# Altium schematic sheet MAIN.SchDoc(MAIN)
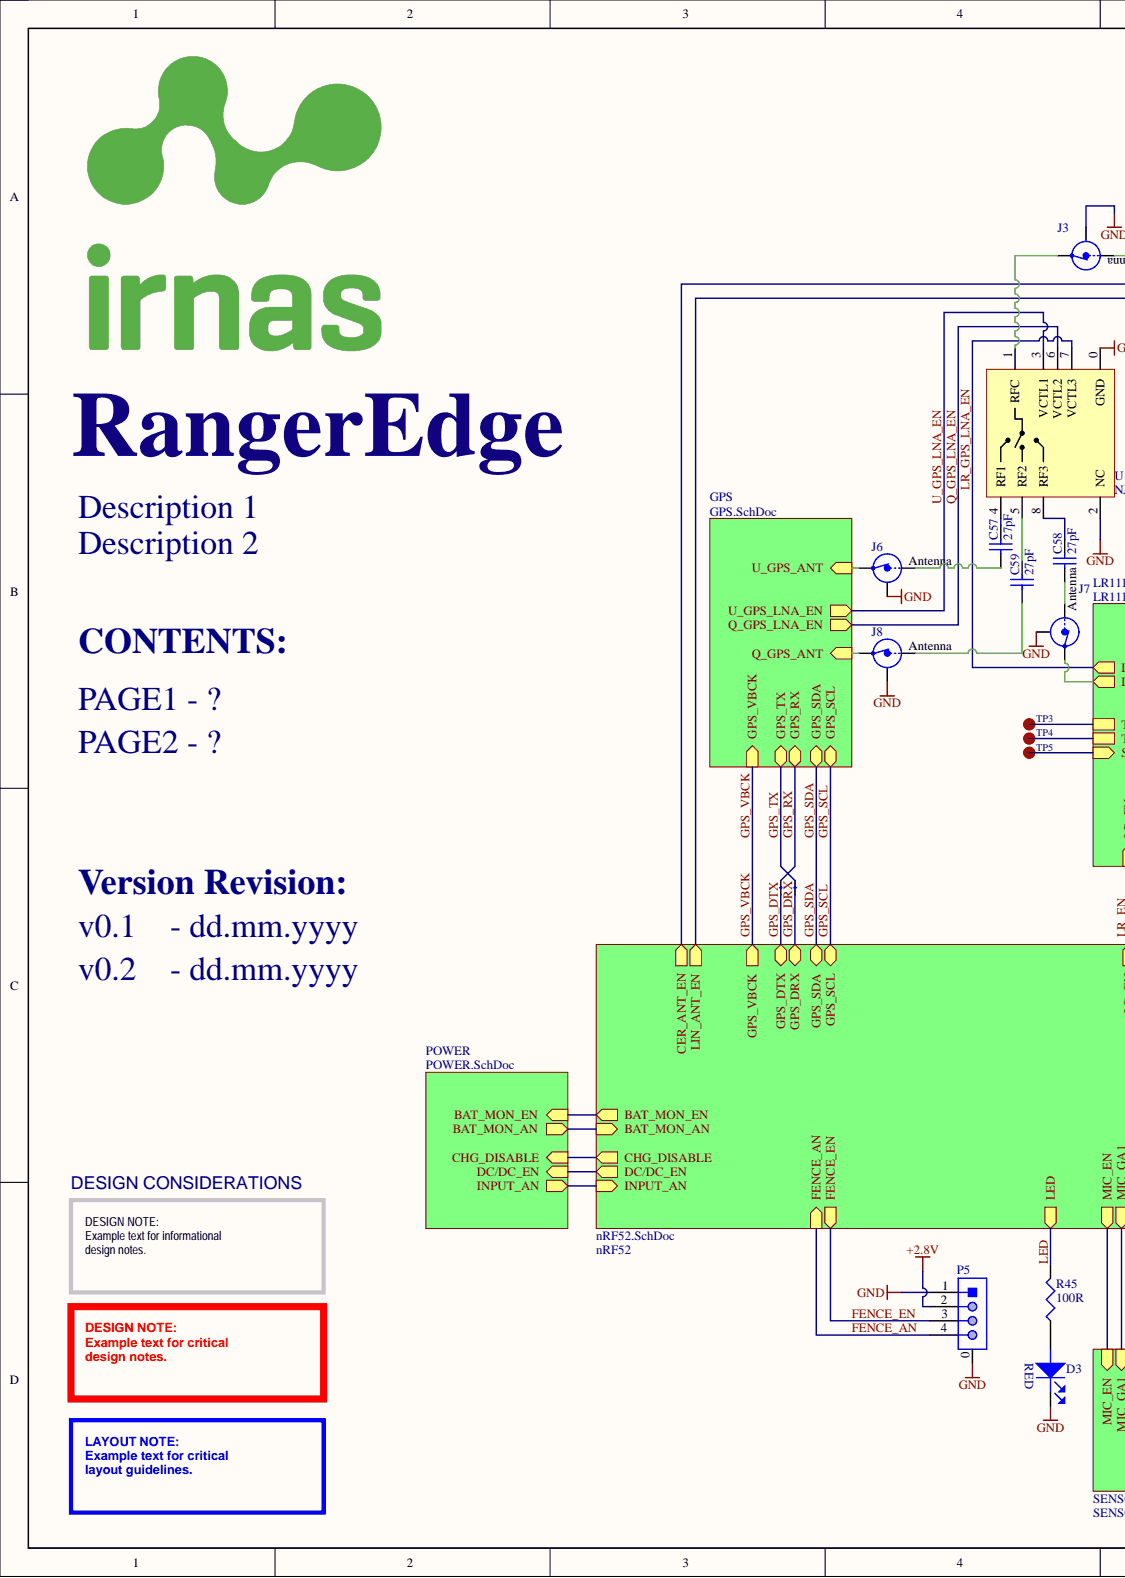
[diagram p0: MAIN.SchDoc, left]
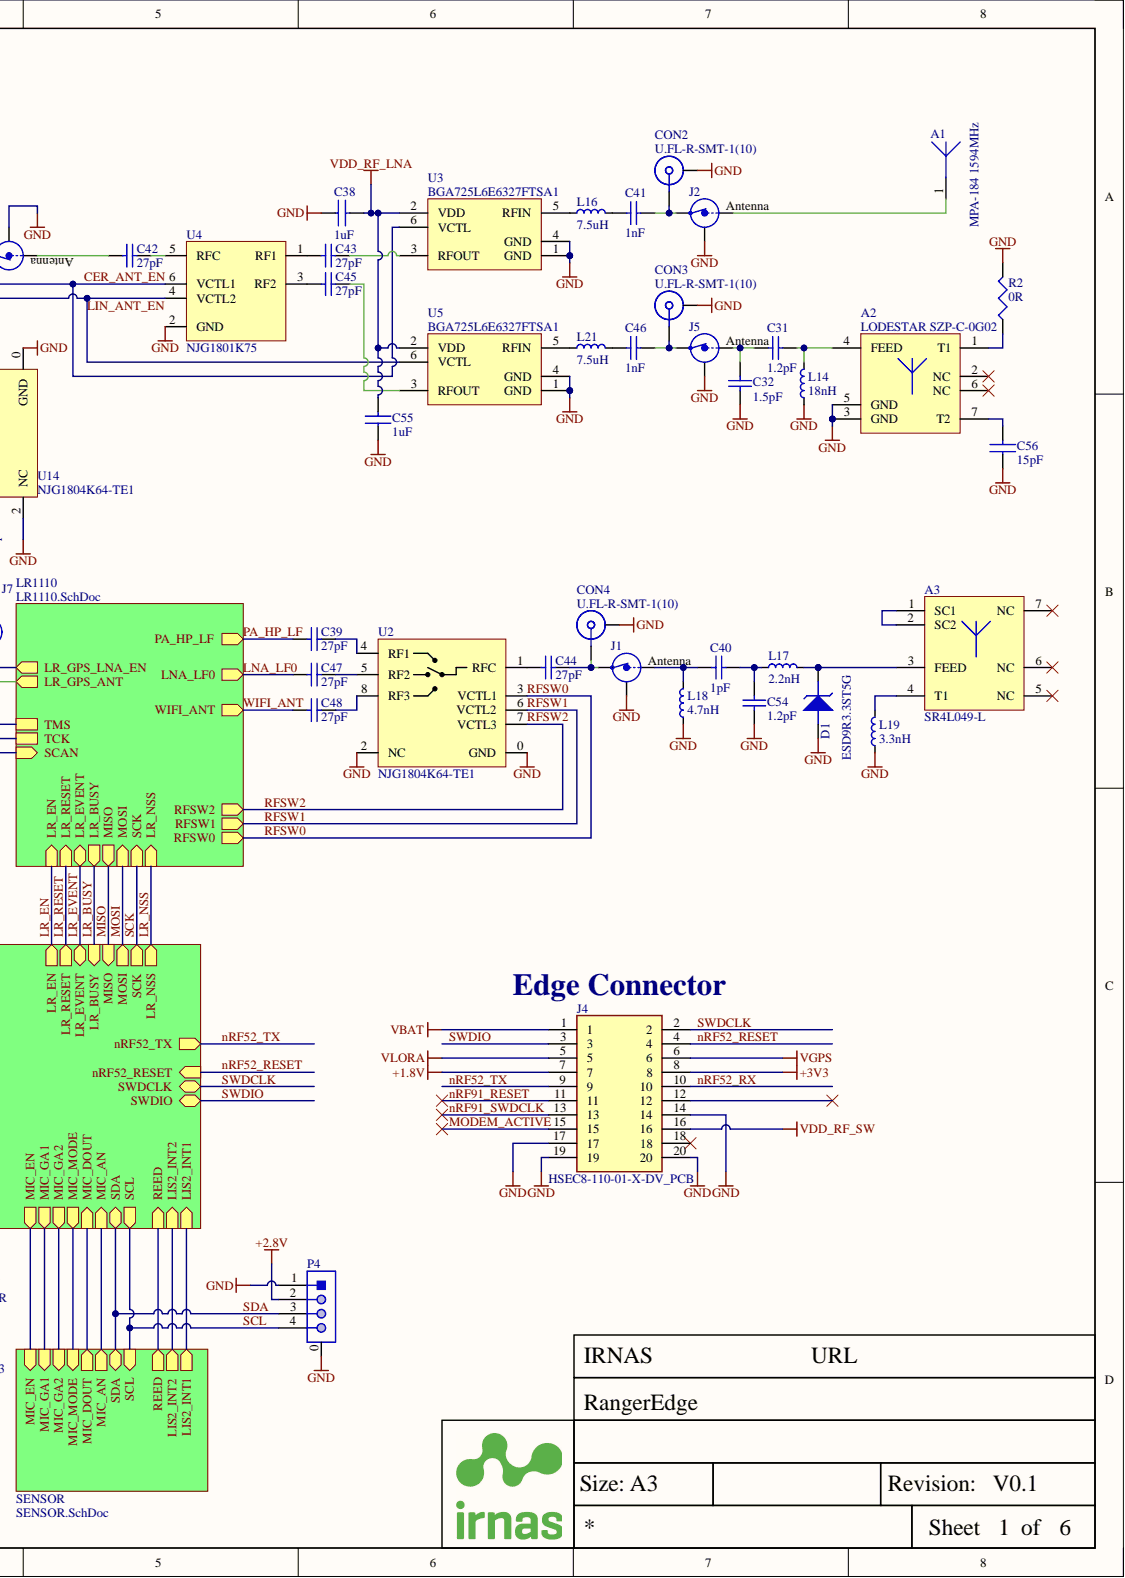
[diagram p1: MAIN.SchDoc, right]

## Parts
A1  MPA-184 1594MHz  [MPA-184]
A2  LODESTAR SZP-C-0G02  Manufacturer Part Number: SZP-C-0G02  [SZP-C-0G02-1]
A3  SR4L049-L  [SR4L049-L]
C31  value 1.2pF  [rlc_402_smd_small]  (hidden comment: 1.2pF/50V/0402)
C32  value 1.5pF  [rlc_402_smd_small]  (hidden comment: 1.5pF/50V/0402)
C38  1uF  [rlc_402_smd_small]
C39  value 27pF  [CAP0402]  (hidden comment: 27pF/50V/0402)
C40  value 1pF  [CAP0402]  (hidden comment: 1pF/50V/0402)
C41  value 1nF  [rlc_402_smd_small]  (hidden comment: 1nF/50V/0402)
C42  value 27pF  [rlc_402_smd_small]  (hidden comment: 27pF/50V/0402)
C43  value 27pF  [rlc_402_smd_small]  (hidden comment: 27pF/50V/0402)
C44  value 27pF  [CAP0402]  (hidden comment: 27pF/50V/0402)
C45  value 27pF  [rlc_402_smd_small]  (hidden comment: 27pF/50V/0402)
C46  value 1nF  [rlc_402_smd_small]  (hidden comment: 1nF/50V/0402)
C47  value 27pF  [CAP0402]  (hidden comment: 27pF/50V/0402)
C48  value 27pF  [CAP0402]  (hidden comment: 27pF/50V/0402)
C54  value 1.2pF  [CAP0402]  (hidden comment: 1.2pF/50V/0402)
C55  1uF  [CAP0402]
C56  15pF  [CAP0402]
C57  value 27pF  [rlc_402_smd_small]  (hidden comment: 27pF/50V/0402)
C58  value 27pF  [rlc_402_smd_small]  (hidden comment: 27pF/50V/0402)
C59  value 27pF  [rlc_402_smd_small]  (hidden comment: 27pF/50V/0402)
CON2  U.FL-R-SMT-1(10)  [ufl connector smd]
CON3  U.FL-R-SMT-1(10)  [ufl connector smd]
CON4  U.FL-R-SMT-1(10)  [ufl connector smd]
D1  ESD9R3.3ST5G  [SOD-923]
D3  KPTL-3216SURCK-01  [LED1206 - Reverse]  (value not drawn)
J1  MM8130-2600  [COAXIAL-SWF]  (value not drawn)
J2  MM8130-2600  [COAXIAL-SWF]  (value not drawn)
J3  MM8130-2600  [COAXIAL-SWF]  (value not drawn)
J4  HSEC8-110-01-X-DV_PCB  [SAMTEC_HSEC8-110-01-X-DV_PCB]  (no BOM)
J5  MM8130-2600  [COAXIAL-SWF]  (value not drawn)
J6  MM8130-2600  [COAXIAL-SWF]  (value not drawn)
J7  MM8130-2600  [COAXIAL-SWF]  (value not drawn)
J8  MM8130-2600  [COAXIAL-SWF]  (value not drawn)
L14  value 18nH  [rlc_402_smd_small]  (hidden comment: 18nH/0402)
L16  value 7.5uH  [rlc_402_smd_small]  (hidden comment: 7.5nH/0402)
L17  2.2nH  [IND0402]
L18  4.7  value 4.7nH  [IND0402]
L19  value 3.3nH  [IND0402]  (hidden comment: 3.3nH/0402)
L21  value 7.5uH  [rlc_402_smd_small]  (hidden comment: 7.5nH/0402)
NT1  =Value  [2 PIN STARPOINT]
NT2  =Value  [2 PIN STARPOINT]
P4  BM04B-SRSS-TB(LF)(SN)  [BM04B-SRSS]  (value not drawn)
P5  BM04B-SRSS-TB(LF)(SN)  [BM04B-SRSS]  (value not drawn)
R2  0R  [RES0402]
R45  100R  [RES0402]
TP3  TestPoint  [TestPoint:1.2x1.2mm]  (value not drawn)
TP4  TestPoint  [TestPoint:1.2x1.2mm]  (value not drawn)
TP5  TestPoint  [TestPoint:1.2x1.2mm]  (value not drawn)
U2  NJG1804K64-TE1  [DFN-8]
U3  BGA725L6E6327FTSA1  [EPFFP6-X2]
U4  NJG1801K75  [DFN-6-JRC]
U5  BGA725L6E6327FTSA1  [EPFFP6-X2]
U14  NJG1804K64-TE1  [DFN-8]

## Nets
+1.8V: J4.7
+2.8V: P4.2 P5.2
+3V3: J4.8
GND: A2.3(GND) A2.5(GND) C32.2 C38.1 C54.1 C55.1 C56.1 CON2.2 CON3.2 CON4.2 D1.A D3.2 J1.2 J2.2 J3.2 J4.14 J4.17 J4.19 J4.20 J5.2 J6.2 J7.2 J8.2 L14.1 L18.1 L19.1 P4.0 P4.1 P5.0 P5.1 R2.2 U2.0(GND) U2.2(NC) U3.1(GND) U3.4(GND) U4.2(GND) U5.1(GND) U5.4(GND) U14.0(GND) U14.2(NC)
VBAT: J4.1
VDD_RF_LNA: C38.2 C55.2 U3.2(VDD) U5.2(VDD)
VDD_RF_SW: J4.16
VGPS: J4.6
VLORA: J4.5
CER_ANT_EN: U3.6(VCTL) U4.6(VCTL1)
FENCE_AN: P5.4
FENCE_EN: P5.3
GPS_DRX: NT2.1
GPS_DTX: NT1.1
GPS_RX: NT1.2
GPS_TX: NT2.2
LED: R45.2
LIN_ANT_EN: U4.4(VCTL2) U5.6(VCTL)
LNA_LF0: C47.1
LR_GPS_LNA_EN: U14.7(VCTL3)
MODEM_ACTIVE: J4.15
nRF52_RESET: J4.4
nRF52_RX: J4.10
nRF52_TX: J4.9
nRF91_RESET: J4.11
nRF91_SWDCLK: J4.13
PA_HP_LF: C39.1
Q_GPS_LNA_EN: U14.6(VCTL2)
RFSW0: U2.3(VCTL1)
RFSW1: U2.6(VCTL2)
RFSW2: U2.7(VCTL3)
SCL: P4.4
SDA: P4.3
SWDCLK: J4.2
SWDIO: J4.3
U_GPS_LNA_EN: U14.3(VCTL1)
WIFI_ANT: C48.1
NetA1_1: A1.1 J2.1
NetA2_1: A2.1(T1) R2.1
NetA2_2: A2.2(NC)
NetA2_4: A2.4(FEED) C31.2 L14.2
NetA2_6: A2.6(NC)
NetA2_7: A2.7(T2) C56.2
NetA3_1: A3.1(SC1) A3.2(SC2)
NetA3_3: A3.3(FEED) D1.K L17.2
NetA3_4: A3.4(T1) L19.2
NetA3_5: A3.5(NC)
NetA3_6: A3.6(NC)
NetA3_7: A3.7(NC)
NetC31_1: C31.1 C32.1 J5.1
NetC39_2: C39.2 U2.4(RF1)
NetC40_1: C40.1 C54.2 L17.1
NetC40_2: C40.2 J1.1 L18.2
NetC41_1: C41.1 L16.2
NetC41_2: C41.2 CON2.1 J2.3
NetC42_1: C42.1 J3.1
NetC42_2: C42.2 U4.5(RFC)
NetC43_1: C43.1 U4.1(RF1)
NetC43_2: C43.2 U3.3(RFOUT)
NetC44_1: C44.1 U2.1(RFC)
NetC44_2: C44.2 CON4.1 J1.3
NetC45_1: C45.1 U4.3(RF2)
NetC45_2: C45.2 U5.3(RFOUT)
NetC46_1: C46.1 L21.2
NetC46_2: C46.2 CON3.1 J5.3
NetC47_2: C47.2 U2.5(RF2)
NetC48_2: C48.2 U2.8(RF3)
NetC57_1: C57.1 J6.1
NetC57_2: C57.2 U14.4(RF1)
NetC58_1: C58.1 J7.1
NetC58_2: C58.2 U14.8(RF3)
NetC59_1: C59.1 J8.1
NetC59_2: C59.2 U14.5(RF2)
NetD3_1: D3.1 R45.1
NetJ3_3: J3.3 U14.1(RFC)
NetJ4_12: J4.12
NetJ4_18: J4.18
NetJ6_3: J6.3
NetJ7_3: J7.3
NetJ8_3: J8.3
NetL16_1: L16.1 U3.5(RFIN)
NetL21_1: L21.1 U5.5(RFIN)
NetTP3_0: TP3.0
NetTP4_0: TP4.0
NetTP5_0: TP5.0
BAT_MON_AN:
BAT_MON_EN:
CHG_DISABLE:
DC/DC_EN:
GPS_SCL:
GPS_SDA:
GPS_VBCK:
INPUT_AN:
LIS2_INT1:
LIS2_INT2:
LR_BUSY:
LR_EN:
LR_EVENT:
LR_NSS:
LR_RESET:
MIC_AN:
MIC_DOUT:
MIC_EN:
MIC_GA1:
MIC_GA2:
MIC_MODE:
MISO:
MOSI:
REED:
SCK:

## Library data of the parts
A1 = ANT - MAXTENA MPA-184 1594MHz: Antennas Passive GPS/GLONASS Patch Antenna; Manufacturer: Antenova; Manufacturer Part Number: SR42I010-R; Component Kind: Standard; ComponentLink1Description: Datasheet; ComponentLink1URL: https://www.maxtena.com/wp-content/uploads/2018/09/maxtena_application_note_patch_antennas.pdf; Library Name: Passive.SchLib; Pricing 1: 1 = 2.36000, 10 = 1.90000, 50 = 1.90000, 100 = 1.52000, 1000 = 1.07000, 10000 = 0.95900 (USD); Stock 1: 3154; Supplier 1: Digi-Key; Supplier 2: Mouser; Supplier Part Number 1: 931-1408-ND; Supplier Part Number 2: 960-CGGP.18.2.A.02
A2 = ANT - LODESTAR SZP-C-0G02: GNSS: GPS, GLONASS, GALILEO, Beidou: 1.559 – 1.610 GHz; Manufacturer: SYNZEN; Component Kind: Standard; Library Name: Passive.SchLib; Pricing 1: 1 = 2.36000, 10 = 1.90000, 50 = 1.90000, 100 = 1.52000, 1000 = 1.07000, 10000 = 0.95900 (USD); Stock 1: 3154
A3 = ANT - SR4L049-L: Antennas Inversa Left LTE Antenna; Manufacturer: Antenova; Component Kind: Standard; Library Name: Passive.SchLib; Manufacturer Part Number: SR4L049-L; Pricing 1: 1 = 2.36000, 10 = 1.90000, 50 = 1.90000, 100 = 1.52000, 1000 = 1.07000, 10000 = 0.95900 (USD); Stock 1: 3154; Supplier 1: Mouser; Supplier Part Number 1: 237-SR4L049-L
C31 = C - 1.2pF/50V/0402: CAP 1.2PF 50V C0G/NP0 0402; IRNAS Part No.: /; Manufacturer: Murata; Manufacturer Part Number: GRM1555C1H1R2BA01D; Tolerance: ±0.1pF; Component Kind: Standard; Library Name: Passive.SchLib; Supplier 1: Digi-Key; Supplier Part Number 1: 490-6209-1-ND; Voltage: 50V
C32 = C - 1.5pF/50V/0402: CAP 1.5PF 50V C0G/NP0 0402; IRNAS Part No.: /; Manufacturer: Murata; Manufacturer Part Number: GRM1555C1H1R5BA01D; Tolerance: ±0.1pF; Component Kind: Standard; Library Name: Passive.SchLib; Supplier 1: Digi-Key; Supplier Part Number 1: 490-6212-1-ND; Voltage: 50V
C38 C55 = C - 1uF/0402: CAP CER 1UF 10V 20% X5R 0402; IRNAS Part No.: /; Manufacturer: Murata; Manufacturer Part Number: GRM155R61A105ME15D; Capacitance: 1uF; Case Code (Imperial): 0402; Case Code (Metric): 1005; Case/Package: 0402; Component Kind: Standard; ComponentLink1Description: https://datasheet.ciiva.com/164/product-164665.pdf; ComponentLink1URL: https://datasheet.ciiva.com/164/product-164665.pdf; ComponentLink2Description: https://datasheet.ciiva.com/11616/product-11616508.pdf; ComponentLink2URL: https://datasheet.ciiva.com/11616/product-11616508.pdf; ComponentLink3Description: https://datasheet.ciiva.com/46418/1769893-46418047.pdf; ComponentLink3URL: https://datasheet.ciiva.com/46418/1769893-46418047.pdf; ComponentLink4Description: https://datasheet.ciiva.com/164/partnumbering-e-01-164666.pdf; ComponentLink4URL: https://datasheet.ciiva.com/164/partnumbering-e-01-164666.pdf; ComponentLink5Description: https://datasheet.ciiva.com/164/partnumbering-e-01-164667.pdf; ComponentLink5URL: https://datasheet.ciiva.com/164/partnumbering-e-01-164667.pdf; Depth: 0.5mm; Dielectric: X5R; Height: 0.5mm; Lead Free: Lead Free; Length: 1mm; Library Name: Passive.SchLib; Max Operating Temperature: 85°C; Min Operating Temperature: -55°C; Mount: Surface Mount; Package Quantity: 1; Packaging: Tape and Reel; Pricing 1: 10=0.0379, 100=0.0266, 500=0.0156, 2500=0.0098, 5000=0.0083 (EUR); Radiation Hardening: No; REACH SVHC: No SVHC; Stock 1: 0; Supplier 1: Farnell; Supplier Part Number 1: 2426953; Thickness: 0.022inch; Tolerance: 20%; Voltage: 10V; Voltage Rating: 10V; Voltage Rating (DC): 10V; Width: 0.02inch
C39 C42-C45 C47 C48 C57-C59 = C - 27pF/50V/0402: CAP CER 27PF 50V C0G/NP0 0402; Component Kind: Standard; LatestRevisionDate: 05-oct-2007  -  Semtech; LatestRevisionNote: Re-released for DXP Platform.; Library Name: Passive.SchLib; Published: 8-Jun-2000; Supplier 1: Digi-Key; Supplier Part Number 1: 490-11384-1-ND; Publisher: Altium Limited
C40 = C - 1pF/50V/0402: CAP CER 1PF 50V C0G/NP0 0402; Component Kind: Standard; LatestRevisionDate: 05-oct-2007  -  Semtech; LatestRevisionNote: Re-released for DXP Platform.; Library Name: Passive.SchLib; Published: 8-Jun-2000; Supplier 1: Digi-Key; Supplier Part Number 1: 490-6206-1-ND; Publisher: Altium Limited
C41 C46 = C - 1nF/50V/0402: CAP CER 1nF 50V C0G/NP0 0402; Component Kind: Standard; LatestRevisionDate: 05-oct-2007  -  Semtech; LatestRevisionNote: Re-released for DXP Platform.; Library Name: Passive.SchLib; Published: 8-Jun-2000; Supplier 1: Farnell; Supplier Part Number 1: 2470415; Publisher: Altium Limited
C54 = C - 1.2pF/50V/0402: CAP CER 1.2PF 50V C0G/NP0 0402; Component Kind: Standard; LatestRevisionDate: 05-oct-2007  -  Semtech; LatestRevisionNote: Re-released for DXP Platform.; Library Name: Passive.SchLib; Published: 8-Jun-2000; Supplier 1: Digi-Key; Supplier Part Number 1: 490-6209-1-ND; Publisher: Altium Limited
C56 = C - 15pF/0402: AVX   04025A150JAT2A   Multilayer Ceramic Capacitor, 15 pF,  5%, C0G / NP0, 50 V, 0402 [1005 Metric]; Manufacturer: Kyocera AVX; Temperature Coefficient: 30ppm/°C; Voltage: 50V; Capacitance: 15pF; Case Code (Imperial): 0402; Case Code (Metric): 1005; Case/Package: 0402; Component Kind: Standard; ComponentLink1Description: https://datasheet.ciiva.com/11786/0900766b80def0e2-11786290.pdf; ComponentLink1URL: https://datasheet.ciiva.com/11786/0900766b80def0e2-11786290.pdf; ComponentLink2Description: https://datasheet.ciiva.com/28533/759117-28533749.pdf; ComponentLink2URL: https://datasheet.ciiva.com/28533/759117-28533749.pdf; ComponentLink3Description: https://datasheet.ciiva.com/1123/84496-1123526.pdf; ComponentLink3URL: https://datasheet.ciiva.com/1123/84496-1123526.pdf; ComponentLink4Description: https://datasheet.ciiva.com/1123/84496-1123527.pdf; ComponentLink4URL: https://datasheet.ciiva.com/1123/84496-1123527.pdf; ComponentLink5Description: https://datasheet.ciiva.com/14587/70001039-14587416.pdf; ComponentLink5URL: https://datasheet.ciiva.com/14587/70001039-14587416.pdf; ComponentLink6Description: https://datasheet.ciiva.com/17489/ccog-17489144.pdf; ComponentLink6URL: https://datasheet.ciiva.com/17489/ccog-17489144.pdf; ComponentLink7Description: https://datasheet.ciiva.com/156/ccog-156941.pdf; ComponentLink7URL: https://datasheet.ciiva.com/156/ccog-156941.pdf; ComponentLink8Description: https://datasheet.ciiva.com/156/ccog-156942.pdf; ComponentLink8URL: https://datasheet.ciiva.com/156/ccog-156942.pdf; ComponentLink9Description: https://datasheet.ciiva.com/2987/ccog-2987646.pdf; ComponentLink9URL: https://datasheet.ciiva.com/2987/ccog-2987646.pdf; ComponentLink10Description: https://datasheet.ciiva.com/7709/759117-7709534.pdf; ComponentLink10URL: https://datasheet.ciiva.com/7709/759117-7709534.pdf; ComponentLink11Description: https://datasheet.ciiva.com/156/ccog-156943.pdf; ComponentLink11URL: https://datasheet.ciiva.com/156/ccog-156943.pdf; ComponentLink12Description: https://datasheet.ciiva.com/2987/ccog-2987647.pdf; ComponentLink12URL: https://datasheet.ciiva.com/2987/ccog-2987647.pdf; Contact Plating: Tin,Matte; Depth: 0.5mm; Dielectric: C0G; Height: 0.5mm; IRNAS Part No.: /; Lead Free: Lead Free; Length: 1mm; Library Name: Passive.SchLib; Manufacturer Part Number: 04025A150JAT2A; Max Operating Temperature: 125°C; Min Operating Temperature: -55°C; Mount: Surface Mount,PCB; Number of Pins: 2; Package Quantity: 1; Packaging: Tape and Reel; Radiation Hardening: No; REACH SVHC: No SVHC; Stock 1: 0; Supplier 1: Farnell; Supplier Part Number 1: 2367620; Termination: SMD/SMT; Thickness: 0.022inch; Tolerance: 5%; Voltage Rating: 50V; Voltage Rating (DC): 50V; Width: 0.5mm
CON2-CON4 = U.FL-R-SMT-1(10): RF / Coaxial Connector, U.FL Coaxial, Straight Jack, Surface Mount Vertical, 50 ohm, Brass; Manufacturer: Hirose; Body Finish: Silver; Body Material: Phosphor Bronze; Center Contact Plating: Gold; Connector Style: U.FL, Ultra Miniature Coaxial; Connector Type: Receptacle, Male Pin; Contact Termination: Solder; Dielectric Material: Liquid Crystal Polymer (LCP); Featured Product: U.FL Series Connectors; Frequency - Max: 6GHz; Impedance: 50 Ohm; Online Catalog: UMCC (Ultra Miniature Coax Connectors), U.FL Series; Other Names: H11891TR  Q5400621  U.FL-R-SMT-1 10  U.FL-R-SMT-1(10)-ND  UFLRSMT110; Cable Group: -; Category: Connectors, Interconnects; Center Contact Material: Brass; Component Kind: Standard; ComponentLink1Description: http://www.farnell.com/datasheets/91524.pdf; ComponentLink1URL: http://www.farnell.com/datasheets/91524.pdf; ComponentLink2Description: http://uk.rs-online.com/webdocs/1363/0900766b81363563.pdf; ComponentLink2URL: http://uk.rs-online.com/webdocs/1363/0900766b81363563.pdf; ComponentLink3Description: http://www.farnell.com/datasheets/1947917.pdf; ComponentLink3URL: http://www.farnell.com/datasheets/1947917.pdf; Family: Coaxial Connectors (RF); Fastening Type: Snap-On; Features: -; Housing Color: Beige; Ingress Protection: -; Library Name: Connectors.SchLib; Manufacturer Part Number: U.FL-R-SMT-1(10); Mounting Type: Surface Mount; Operating Temperature: -40°C ~ 90°C; Packaging: Tape & Reel (TR); Series: U.FL; Shield Termination: Solder; Standard Package: 2,500; Stock 1: 0; Supplier 1: Farnell; Supplier Part Number 1: 1688077; Voltage Rating: -
D1 = D_TVS - ESD9R3.3ST5G: TVS Diode, ESD9R Series, Unidirectional, 3.3 V, 7.8 V; Manufacturer: DIODES INC.; Manufacturer Part Number: 1N4148W-7-F.; Diode Case Style: SOD-123; Diode Configuration: Single; Diode Type: Switching; Forward Current If(AV): 300; Forward Surge Current Ifsm Max: 2; Forward Voltage VF Max: 1.25; Product Range: 1N4148 Series; Repetitive Reverse Voltage Vrrm Max: 100; Reverse Recovery Time trr Max: 4; SVHC: No SVHC (17-Dec-2015); Automotive Qualification Standard: -; Component Kind: Standard; ComponentLink1Description: Datasheet; Library Name: Active.SchLib; MSL: MSL 1 - Unlimited; No. of Pins: 2; Operating Temperature Max: 150; Operating Temperature Min: -65; Operating Temperature Range: -65°C to +150°C<!--FANDB--><div class="features-and-benefits-container"><div class="features-and-benefits-description"><span class="features-benefits-value">The 1N4148W-7-F is a surface mount fast Switching Diode with moulded plastic case. Suitable for general purpose switching applications.</span></div><div class="features-and-benefits-list"><ul class="features-and-benefits-list-list"><li class="features-and-benefits-list-item">Fast Switching Speed</li><li class="features-and-benefits-list-item">2; Packaging: Cut Tape; Pricing 1: 1=0.136, 25=0.132, 100=0.0465, 500=0.042, 1000=0.024, 3000=0.021, 9000=0.017, 30000=0.0149 (GBP); RoHS: YES; Stock 1: 157320; Supplier 1: Farnell; Supplier Part Number 1: 1776392; ComponentLink1URL: D:\altium\Altium_Library\Active\Datasheet\ESD9R33ST5G.pdf
D3 = LED - KPTL-3216SURCK-01: LED, Low Power, Red, SMD - Reverse Mount, 1206 (3216 Metric), 20 mA, 1.95 V, 630 nm; Manufacturer: KINGBRIGHT; Forward Current If: 20; Forward Voltage: 2.1; Lens Shape: Rectangular; Luminous Intensity: 10; SVHC: No SVHC (17-Dec-2015)<!--FANDB--><div class="features-and-benefits-container"><div class="features-and-benefits-description"><span class="features-benefits-value">The HSMS-C190 is a HER SMD Chip LED with untinted/diffused lens, made of GaP. It is designed in an industry standard package 1.6 x 0.8mm footprint with 0.8mm profile. It is compatible with IR reflow solder processes. The small size and wide viewing angle make this LED prime choices for backlighting applications and front panel illumination especially where space is a premium.</span></div><div class="features-and-benefits-list"><ul class="features-and-benefits-list-list"><li class="features-and-benefits-list-item">Small size</li><li class="features-and-benefits-list-item">Industry standard footprint</li><li class="features-and-benefits-list-item">Compatible with IR solder</li><li class="features-and-benefits-list-item">Diffused optics</li><li class="features-and-benefits-list-item">-40 to 85; Viewing Angle: 170°; Wavelength Typ: 626; Automotive Qualification Standard: -; Bulb Size: -; Component Kind: Standard; ComponentLink1Description: Datasheet; ComponentLink1URL: http://www.farnell.com/datasheets/1655888.pdf; LED Colour: Red; LED Mounting: SMD; Library Name: Active.SchLib; Manufacturer Part Number: KPTL-3216SURCK-01; MSL: -; Packaging: Cut Tape; Pricing 1: 1=0.361, 10=0.256, 50=0.235, 100=0.106, 200=0.101 (GBP); Product Range: -; RoHS: YES; Stock 1: 7534; Supplier 1: Farnell; Supplier Part Number 1: 2217903
J1-J3 J5-J8 = (no symbol name): Coaxial Connector with Switch; 2nd source part number: 81-MM8130-2600RA2; Manufacturer: Murata; Artikkelnr Noca: 55029011; Artikkelnr Simpro: N.A.; 2nd source Supplier: Mouser; Component Kind: Standard; Datasheet: http://www.murata.com/products/catalog/pdf/o33e.pdf; Footprint Doc: COAXIAL-SWF; LatestRevisionDate: 2014-04-24; Library Name: Connectors.SchLib; Manufacturer Part Number: MM8130-2600; Part Number: MM8130-2600; Pin Count: 3; Published: 2014-04-24; Supplier: Digi-Key; Supplier part number: 490-4981-1-ND; Value: MM8130-2600; Publisher: Nordic Semiconductor
J4 = HSEC8-110-01-X-DV_PCB: 20 Position Female Connector Non Specified - Dual Edge Gold 0.031 (0.80mm) Black; IRNAS Part No.: /; Value: /; Library Name: Connectors.SchLib; Manufacturer: /; Manufacturer Part Number: /; Supplier 1: /; Supplier Part Number 1: /; Tolerance: /; Voltage: /
L14 = L - 18nH/230mA/0402: IND 18NH 260MA 0.8 OHM 0402; IRNAS Part No.: /; Manufacturer: MURATA; Manufacturer Part Number: LQG15WH18NJ02D; Tolerance: 5%; Component Kind: Standard; Library Name: Passive.SchLib; Supplier 1: Farnell; Supplier Part Number 1: 3263541; Voltage: /
L16 L21 = L - 7.5nH/570mA/0402: FIXED IND 7.5NH 570MA 130 MOHM; Manufecturer: Murata; Manufecturer part number: LQW15AN7N5G00D; Component Kind: Standard; Library Name: Passive.SchLib; Supplier 1: Digi-Key; Supplier Part Number 1: 490-6846-2-ND
L17 = L - 2.2nH/750mA/0402: +FIXED IND 4.7NH 750MA 70MOHM; Code_IEC: 1005; Code_JEITA: 0402; Component Kind: Standard; LatestRevisionDate: 05-oct-2007  -  Semtech; LatestRevisionNote: Re-released for DXP Platform.; Library Name: Passive.SchLib; Published: 8-Jun-2000; Supplier 1: Farnell; Supplier Part Number 1: 2285651; Value: 2.2nH; Publisher: Altium Limited
L18 = L - 4.7nH/750mA/0402: FIXED IND 4.7NH 750MA 70MOHM; Code_IEC: 1005; Code_JEITA: 0402; Component Kind: Standard; LatestRevisionDate: 05-oct-2007  -  Semtech; LatestRevisionNote: Re-released for DXP Platform.; Library Name: Passive.SchLib; Published: 8-Jun-2000; Supplier 1: Digi-Key; Supplier Part Number 1: 490-1139-1-ND; Publisher: Altium Limited
L19 = L - 3.3nH/2000mA/0402: FIXED IND 3.3NH 2A 30 MOHM SMD; Code_IEC: 1005; Code_JEITA: 0402; Component Kind: Standard; LatestRevisionDate: 05-oct-2007  -  Semtech; LatestRevisionNote: Re-released for DXP Platform.; Library Name: Passive.SchLib; Published: 8-Jun-2000; Supplier 1: Digi-Key; Supplier Part Number 1: 490-7642-1-ND; Publisher: Altium Limited
NT1 NT2 = (no symbol name): Starpoint; Component Kind: Net Tie; Library Name: Passive.SchLib
P4 P5 = JST - BM04B-SRSS-TB(LF)(SN): Pin Header, Top Entry, Wire-to-Board, 1 mm, 1 Rows, 4 Contacts, Surface Mount, SR Series; Gender: Male; Pin Number: 4; Pitch: 1mm; Component Kind: Standard; ComponentLink1Description: Manufacturer; ComponentLink1URL: http://www.we-online.de; ComponentLink2Description: Datasheet; ComponentLink2URL: http://katalog.we-online.de/em/datasheet/691305130004.pdf; Library Name: Connectors.SchLib; Mounting Technology: THT; Operating Temperature: -40 to 105 degC; Packaging: Box; Part Number: BM04B-SRSS-TB(LF)(SN); Published Date: June 2018; Supplier 1: Farnell; Supplier Part Number 1: 1679129; Publisher: JST
R2 = R - 0R/0402: RESISTOR, 0402, 0R, ANTI SULFURATION; IRNAS Part No.: I-100016; Manufacturer: Multicomp; Manufacturer Part Number: MCMR04X000PTL; Power Rating: 62.5mW; Resistance: 0mR; Temperature Coefficient: 400ppm/°C; Voltage Rating: 50V; Case Code (Imperial): 0402; Case Code (Metric): 1005; Case/Package: 0402; Component Kind: Standard; ComponentLink1Description: https://datasheet.ciiva.com/7776/1716705-7776974.pdf; ComponentLink1URL: https://datasheet.ciiva.com/7776/1716705-7776974.pdf; ComponentLink2Description: https://datasheet.ciiva.com/28677/1716705-28677161.pdf; ComponentLink2URL: https://datasheet.ciiva.com/28677/1716705-28677161.pdf; Composition: Ceramic; Library Name: Passive.SchLib; Packaging: Cut Tape; Pricing 1: 10=0.0057, 100=0.0034, 500=0.0024, 2500=0.0019, 5000=0.0015 (EUR); REACH SVHC: No SVHC; Stock 1: 0; Supplier 1: Farnell; Supplier Part Number 1: 2072513; Voltage Rating (DC): 50V
R45 = R - 100R/0402: MULTICOMP         MCMR04X1000FTL            SMD Chip Resistor, Ceramic, MCMR Series, 100 ohm, 50 V, 0402 [1005 Metric], 62.5 mW,  1%; IRNAS Part No.: /; Power Rating: 62.5mW; Temperature Coefficient: 100ppm/°C; Voltage Rating: 50V; Case Code (Imperial): 0402; Case Code (Metric): 1005; Case/Package: 0402; Component Kind: Standard; ComponentLink1Description: https://datasheet.ciiva.com/28692/1716705-28692087.pdf; ComponentLink1URL: https://datasheet.ciiva.com/28692/1716705-28692087.pdf; ComponentLink2Description: https://datasheet.ciiva.com/22708/1716705-22708156.pdf; ComponentLink2URL: https://datasheet.ciiva.com/22708/1716705-22708156.pdf; Composition: Ceramic; Library Name: Passive.SchLib; Manufacturer: Multicomp; Manufacturer Part Number: MCMR04X1000FTL; Packaging: Cut Tape; Pricing 1: 10=0.0071, 100=0.0042, 500=0.003, 2500=0.0024, 5000=0.0019 (GBP); REACH SVHC: No SVHC; Resistance: 100R; Stock 1: 62365; Supplier 1: Farnell; Supplier Part Number 1: 2072515; Tolerance: 1%; Voltage Rating (DC): 50V
TP3-TP5 = TestPoint: TEST POINT ROUND SMD; FIT: 0.94; Component Kind: Standard; ComponentLink1Description: Datasheet; Komp / Device: SMD; Library Name: Passive.SchLib
U2 U14 = RF - NJG1804K64-TE1: SP3T SWITCH GAAS MMIC; Manufacturer: NJRC; Component Kind: Standard; ComponentLink1Description: Datasheet; ComponentLink1URL: https://eu.mouser.com/datasheet/2/472/SKY65723_81_203923G-1524715.pdf; Library Name: Active.SchLib; Manufacturer Part Number: NJG1804K64; Stock 1: 10805; Supplier 1: Digi-Key; Supplier Part Number 1: 190-NJG1804K64-TE1CT-ND
U3 U5 = RF - BGA725L6E6327FTSA1: RF Amplifier IC, 20 dB Gain / 0.65 dB Noise, 1.55 GHz to 1.615 GHz; Manufacturer: INFINEON; Component Kind: Standard; ComponentLink1Description: Datasheet; ComponentLink1URL: https://www.njr.com/electronic_device/PDF/NJG1155UX2_E.pdf; Library Name: Active.SchLib; Manufacturer Part Number: BGA725L6E6327FTSA1; Stock 1: 10805; Supplier 1: Farnell; Supplier Part Number 1: 2480589
U4 = RF - NJG1801K75: RF Switch ICs SPDT GaAs MMIC 6.0GHz 3.0V 31dBm; Manufacturer: NJR; Component Kind: Standard; ComponentLink1Description: Datasheet; ComponentLink1URL: https://eu.mouser.com/datasheet/2/472/SKY65723_81_203923G-1524715.pdf; Library Name: Active.SchLib; Manufacturer Part Number: NJG1801K75; Stock 1: 10805; Supplier 1: Mouser; Supplier Part Number 1: 513-NJG1801K75
